# Altium schematic sheet BLDC_Ver0.SchDoc
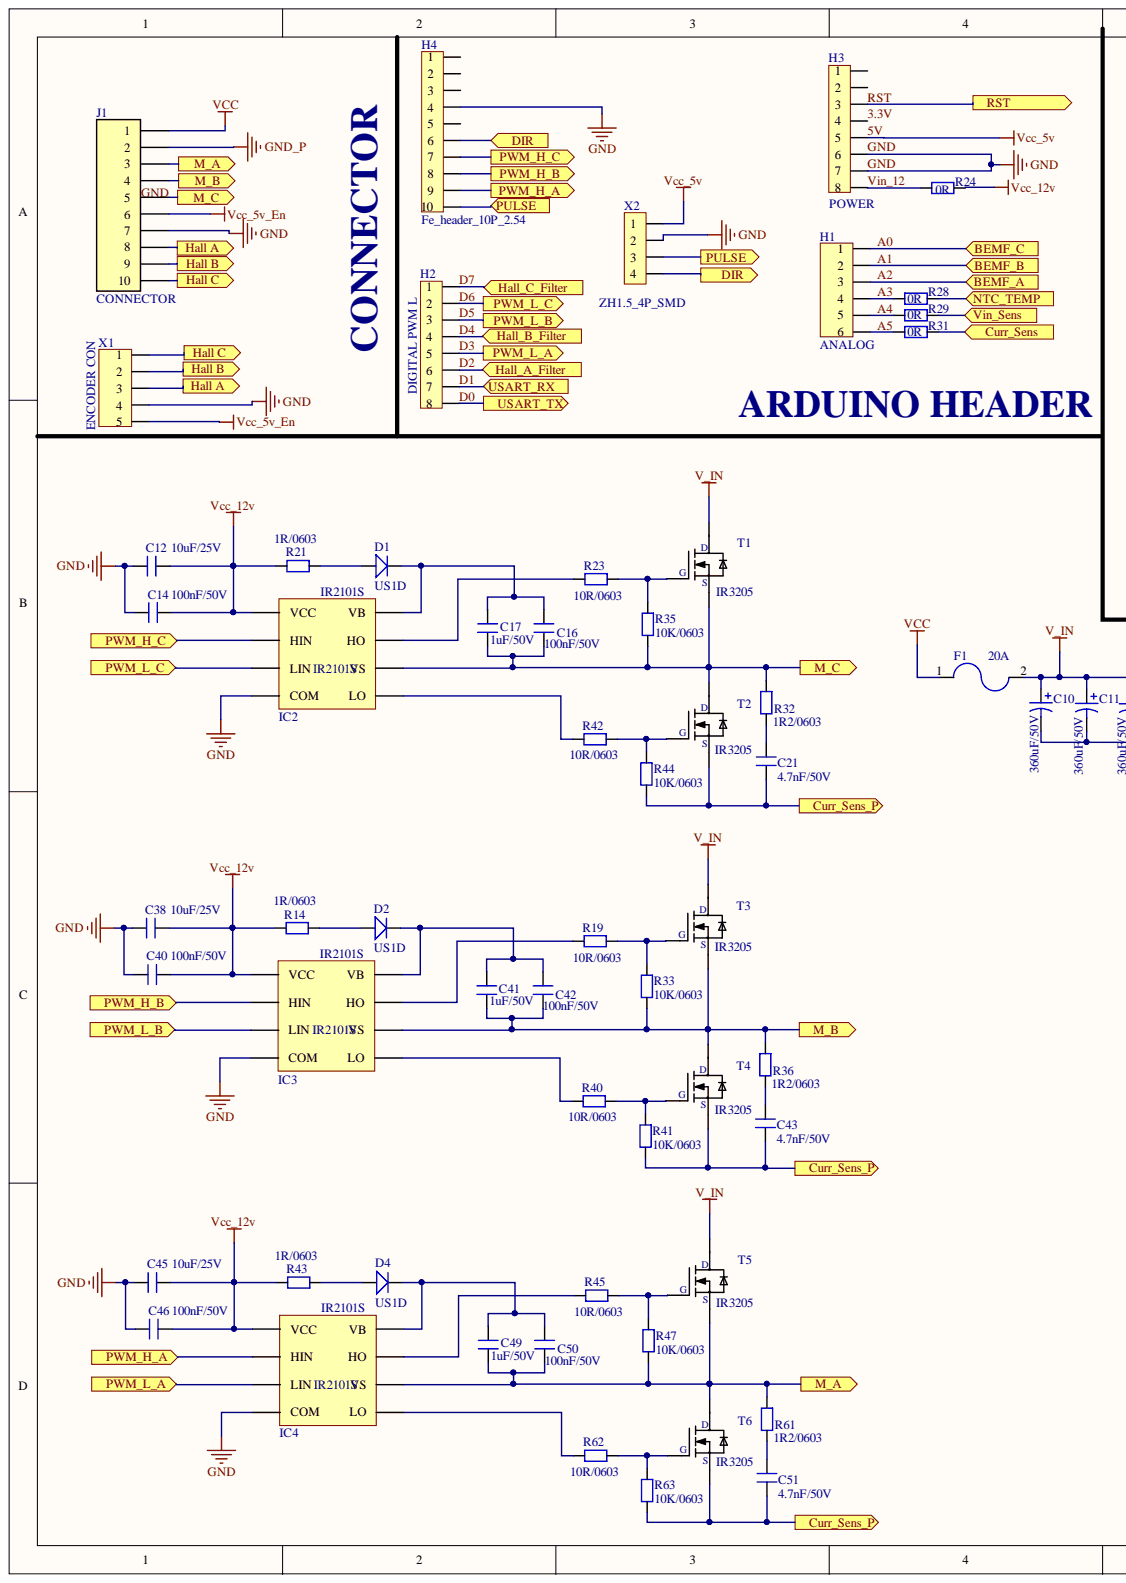
[diagram p0: BLDC_Ver0.SchDoc, left]
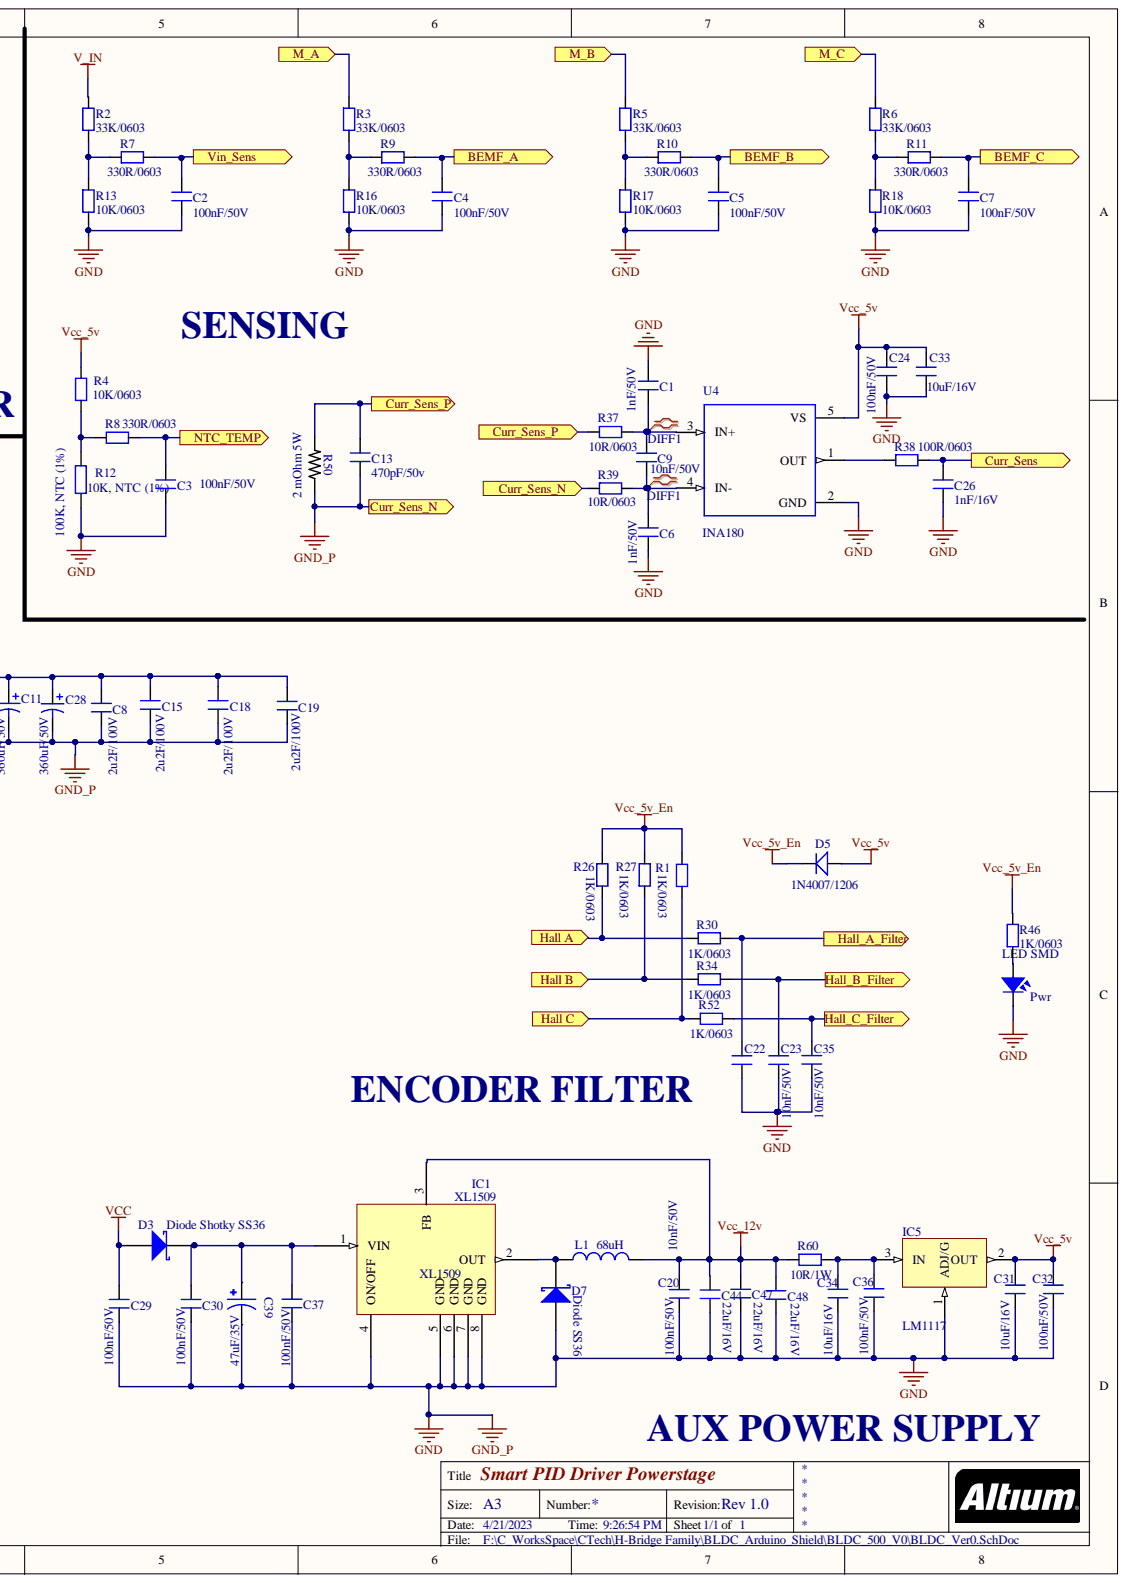
[diagram p1: BLDC_Ver0.SchDoc, right]

## Parts
C1  1nF/50V  [C0603]
C2  100nF/50V  [C0603]
C3  100nF/50V  [C0603]
C4  100nF/50V  [C0603]
C5  100nF/50V  [C0603]
C6  1nF/50V  [C0603]
C7  100nF/50V  [C0603]
C8  2u2F/100V  [C1206]
C9  10nF/50V  [C0805]
C10  360uF/50V  [*CAPAE830X1050N]
C11  360uF/50V  [*CAPAE830X1050N]
C12  10uF/25V  [C0805]
C13  470pF/50v  [C1206]
C14  100nF/50V  [C0603]
C15  2u2F/100V  [C1206]
C16  100nF/50V  [C0603]
C17  1uF/50V  [C0805]
C18  2u2F/100V  [C1206]
C19  2u2F/100V  [C1206]
C20  100nF/50V  [C0603]
C21  4.7nF/50V  [C0603]
C22  10nF/50V  [C0603]
C23  10nF/50V  [C0603]
C24  100nF/50V  [C0603]
C26  1nF/16V  [C0603]
C28  360uF/50V  [*CAPAE830X1050N]
C29  100nF/50V  [C0603]
C30  100nF/50V  [C0603]
C31  10uF/16V  [C0603]
C32  100nF/50V  [C0603]
C33  10uF/16V  [C0603]
C34  10uF/16V  [C0603]
C35  10nF/50V  [C0603]
C36  100nF/50V  [C0603]
C37  100nF/50V  [C0603]
C38  10uF/25V  [C0805]
C39  47uF/35V  [CAP_ALU_6.3x7.7]
C40  100nF/50V  [C0603]
C41  1uF/50V  [C0805]
C42  100nF/50V  [C0603]
C43  4.7nF/50V  [C0603]
C44  22uF/16V  [C1206]
C45  10uF/25V  [C0805]
C46  100nF/50V  [C0603]
C47  22uF/16V  [C1206]
C48  22uF/16V  [C1206]
C49  1uF/50V  [C0805]
C50  100nF/50V  [C0603]
C51  4.7nF/50V  [C0603]
D1  US1D  [SMA_DO-214AC]
D2  US1D  [SMA_DO-214AC]
D3  Diode Shotky SS36  [DIODE_SMC]
D4  US1D  [SMA_DO-214AC]
D5  1N4007/1206  [D_SOD123FL]
D7  Diode SS36  [*SMB_SS34(Primary)]
F1  20A  [Fuse_Nano2]
H1  ANALOG  [Fe_Header_6p_2.54]
H2  DIGITAL PWM L  [Fe_Header_8p_2.54]
H3  POWER  [Fe_Header_8p_2.54]
H4  Fe_header_10P_2.54  [Fe_Header_10p_2.54]
IC1  XL1509  [SOIC-8]
IC2  IR2101S  [SOIC-8]
IC3  IR2101S  [SOIC-8]
IC4  IR2101S  [SOIC-8]
IC5  LM1117  [SOT223_N]
J1  CONNECTOR  [PHOENIX_MSTB_10P_V_5.08]
L1  68uH  [*INDM7676X435N]
Pwr  LED SMD  [SM-LED-0805-Blue]
R1  1K/0603  [R0603]
R2  33K/0603  [R0603]
R3  33K/0603  [R0603]
R4  10K/0603  [R0603]
R5  33K/0603  [R0603]
R6  33K/0603  [R0603]
R7  330R/0603  [R0603]
R8  330R/0603  [R0603]
R9  330R/0603  [R0603]
R10  330R/0603  [R0603]
R11  330R/0603  [R0603]
R12  10K, NTC (1%)  value 100K, NTC (1%)  [RESC2012N]
R13  10K/0603  [R0603]
R14  1R/0603  [R0603]
R16  10K/0603  [R0603]
R17  10K/0603  [R0603]
R18  10K/0603  [R0603]
R19  10R/0603  [R0603]
R21  1R/0603  [R0603]
R23  10R/0603  [R0603]
R24  0R  [R0603]
R26  1K/0603  [R0603]
R27  1K/0603  [R0603]
R28  0R  [R0603]
R29  0R  [R0603]
R30  1K/0603  [R0603]
R31  0R  [R0603]
R32  1R2/0603  [R0603]
R33  10K/0603  [R0603]
R34  1K/0603  [R0603]
R35  10K/0603  [R0603]
R36  1R2/0603  [R0603]
R37  10R/0603  [R0603]
R38  100R/0603  [R0603]
R39  10R/0603  [R0603]
R40  10R/0603  [R0603]
R41  10K/0603  [R0603]
R42  10R/0603  [R0603]
R43  1R/0603  [R0603]
R44  10K/0603  [R0603]
R45  10R/0603  [R0603]
R46  1K/0603  [R0603]
R47  10K/0603  [R0603]
R50  2 mOhm 5W  [*RESC10550X48N]
R52  1K/0603  [R0603]
R60  10R/1W  [2512R]
R61  1R2/0603  [R0603]
R62  10R/0603  [R0603]
R63  10K/0603  [R0603]
T1  IR3205  [DDPAK_TO263-3]
T2  IR3205  [DDPAK_TO263-3]
T3  IR3205  [DDPAK_TO263-3]
T4  IR3205  [DDPAK_TO263-3]
T5  IR3205  [DDPAK_TO263-3]
T6  IR3205  [DDPAK_TO263-3]
U4  INA180  [SOT23-5]
X1  ENCODER CON  [Connector_ZH1.5_5P]
X2  ZH1.5_4P_SMD  [ZH1.5_4P_SMD_SIDE]

## Ports
BEMF_A, BEMF_B, BEMF_C, Curr_Sens, Curr_Sens_N, Curr_Sens_P, DIR, Hall A, Hall B, Hall C, Hall_A_Filter, Hall_B_Filter, Hall_C_Filter, M_A, M_B, M_C, NTC_TEMP, PULSE, PWM_H_A, PWM_H_B, PWM_H_C, PWM_L_A, PWM_L_B, PWM_L_C, RST, USART_RX, USART_TX, Vin_Sens

## Nets
V_IN: C8.2 C10.1 C11.1 C15.2 C18.2 C19.2 C28.1 F1.2 R2.1 T1.2 T3.2 T5.2
VCC: C29.2 D3.1 F1.1 J1.1
Vcc_5v_En: D5.2 J1.6 R1.2 R26.2 R27.2 R46.2 X1.5
Vcc_12v: C12.2 C14.1 C20.2 C38.2 C40.1 C44.2 C45.2 C46.1 C47.2 C48.2 IC1.3(FB) IC2.1(VCC) IC3.1(VCC) IC4.1(VCC) L1.2 R14.2 R21.2 R24.2 R43.2 R60.1
3.3V: H3.4
5V: C24.2 C31.2 C32.2 C33.2 D5.1 H3.5 IC5.2 R4.2 U4.5(VS) X2.1
A0: C7.2 H1.1 R11.2 ; port BEMF_C
A1: C5.2 H1.2 R10.2 ; port BEMF_B
A2: C4.2 H1.3 R9.2 ; port BEMF_A
A3: H1.4 R28.1
A4: H1.5 R29.1
A5: H1.6 R31.1
Curr_Sens: C26.2 R31.2 R38.2 ; port Curr_Sens
Curr_Sens_P: C13.2 C21.2 C43.2 C51.2 R37.1 R41.2 R44.2 R50.2 R63.2 T2.3 T4.3 T6.3 ; port Curr_Sens_P
D0: H2.8 ; port USART_TX
D1: H2.7 ; port USART_RX
D2: C22.2 H2.6 R30.2 ; port Hall_A_Filter
D3: H2.5 IC4.3(LIN) ; port PWM_L_A
D4: C23.2 H2.4 R34.2 ; port Hall_B_Filter
D5: H2.3 IC3.3(LIN) ; port PWM_L_B
D6: H2.2 IC2.3(LIN) ; port PWM_L_C
D7: C35.2 H2.1 R52.2 ; port Hall_C_Filter
DIR: H4.6 X2.4 ; port DIR
GND: C1.2 C2.1 C3.1 C4.1 C5.1 C6.1 C7.1 C8.1 C10.2 C11.2 C12.1 C13.1 C14.2 C15.1 C18.1 C19.1 C20.1 C22.1 C23.1 C24.1 C26.1 C28.2 C29.1 C30.1 C31.1 C32.1 C33.1 C34.1 C35.1 C36.1 C37.1 C38.1 C39.2 C40.2 C44.1 C45.1 C46.2 C47.1 C48.1 D7.1 H3.6 H3.7 H4.4 IC1.4(ON/OFF) IC1.5(GND) IC1.6(GND) IC1.7(GND) IC1.8(GND) IC2.4(COM) IC3.4(COM) IC4.4(COM) IC5.1 J1.2 J1.7 Pwr.2 R12.1 R13.2 R16.2 R17.2 R18.2 R39.1 R50.1 U4.2(GND) X1.4 X2.2 ; port Curr_Sens_N
Hall A: J1.8 R26.1 R30.1 X1.3 ; port Hall A
Hall B: J1.9 R27.1 R34.1 X1.2 ; port Hall B
Hall C: J1.10 R1.1 R52.1 X1.1 ; port Hall C
M_A: C49.1 C50.2 IC4.6(VS) J1.3 R3.1 R47.2 R61.1 T5.3 T6.2 ; port M_A
M_B: C41.1 C42.2 IC3.6(VS) J1.4 R5.1 R33.2 R36.1 T3.3 T4.2 ; port M_B
M_C: C16.2 C17.1 IC2.6(VS) J1.5 R6.1 R32.1 R35.2 T1.3 T2.2 ; port M_C
NTC_TEMP: C3.2 R8.1 R28.2 ; port NTC_TEMP
PULSE: H4.10 X2.3 ; port PULSE
PWM_H_A: H4.9 IC4.2(HIN) ; port PWM_H_A
PWM_H_B: H4.8 IC3.2(HIN) ; port PWM_H_B
PWM_H_C: H4.7 IC2.2(HIN) ; port PWM_H_C
RST: H3.3 ; port RST
Vin_12: H3.8 R24.1
Vin_Sens: C2.2 R7.2 R29.2 ; port Vin_Sens
NetC1_1: C1.1 C9.1 R37.2 U4.3(IN+)
NetC6_2: C6.2 C9.2 R39.2 U4.4(IN-)
NetC16_1: C16.1 C17.2 D1.2 IC2.8(VB)
NetC21_1: C21.1 R32.2
NetC30_2: C30.2 C37.2 C39.1 D3.2 IC1.1(VIN)
NetC34_2: C34.2 C36.2 IC5.3 R60.2
NetC41_2: C41.2 C42.1 D2.2 IC3.8(VB)
NetC43_1: C43.1 R36.2
NetC49_2: C49.2 C50.1 D4.2 IC4.8(VB)
NetC51_1: C51.1 R61.2
NetD1_1: D1.1 R21.1
NetD2_1: D2.1 R14.1
NetD4_1: D4.1 R43.1
NetD7_2: D7.2 IC1.2(OUT) L1.1
NetIC2_5: IC2.5(LO) R42.2
NetIC2_7: IC2.7(HO) R23.2
NetIC3_5: IC3.5(LO) R40.2
NetIC3_7: IC3.7(HO) R19.2
NetIC4_5: IC4.5(LO) R62.2
NetIC4_7: IC4.7(HO) R45.2
NetPwr_1: Pwr.1 R46.1
NetR2_2: R2.2 R7.1 R13.1
NetR3_2: R3.2 R9.1 R16.1
NetR4_1: R4.1 R8.2 R12.2
NetR5_2: R5.2 R10.1 R17.1
NetR6_2: R6.2 R11.1 R18.1
NetR19_1: R19.1 R33.1 T3.1
NetR23_1: R23.1 R35.1 T1.1
NetR38_1: R38.1 U4.1(OUT)
NetR40_1: R40.1 R41.1 T4.1
NetR42_1: R42.1 R44.1 T2.1
NetR45_1: R45.1 R47.1 T5.1
NetR62_1: R62.1 R63.1 T6.1

Not connected: H3 1 2; H4 1 2 3 5

## Library data of the parts
C1-C7 C14 C16 C20-C24 C26 C29-C37 C40 C42 C43 C46 C50 C51 = C0603: Ceramic Capacitor 0603; Component Kind: Standard; Library Name: Daniel_Lib.IntLib
C8 C13 C15 C18 C19 C44 C47 C48 = C1206: Ceramic Capacitor 1206; Component Kind: Standard; Library Name: Daniel_Lib.IntLib
C9 C12 C17 C38 C41 C45 C49 = C0805: Ceramic Capacitor 0805; Component Kind: Standard; Library Name: Daniel_Lib.IntLib
C10 C11 C28 = Cap Pol2: Polarized Capacitor (Axial); Component Kind: Standard; LatestRevisionDate: 17-Jul-2002; LatestRevisionNote: Re-released for DXP Platform.; PackageDescription: Polarized Capacitor; 2 Leads; PackageReference: POLAR0.8; Published: 8-Jun-2000; Library Name: TrieuLIB.IntLib; Publisher: Altium Limited
C39 = Cap_Alu_6.3x7.7: Cap Aluminum Lytic 6.3 X 7.7mm_ SMD; MF: Panasonic Electronic; MP: EEE-FTC331XAP; Availability: Unavailable; Component Kind: Standard; Package: Radial Panasonic; Price: None; SnapEDA_Link: https://www.snapeda.com/parts/EEE-FTC331XAP/Panasonic%20Electronic%20Components/view-part/?ref=snap; Library Name: Daniel_Lib.IntLib
D1 D2 D4 = Diode_RS1X: Diode Rectifier RS1X - 1A; Component Kind: Standard; Library Name: Daniel_Lib.IntLib
D3 D7 = D Schottky: Schottky Diode; Code_JEDEC: DO-214-AA; Component Kind: Standard; LatestRevisionDate: 17-Jul-2002; LatestRevisionNote: Re-released for DXP Platform.; Library Name: myIntegratedLIB.IntLib; PackageDescription: DO-214-AA/SMB; 2 C-Bend Leads; Body 5.3 x 3.6 mm, inc. leads (LxW); PackageReference: SMB; Published: 8-Jun-2000; Publisher: Altium Limited
D5 = Diode_SOD123FL: Diode SOD123FL; Component Kind: Standard; Library Name: Daniel_Lib.IntLib
F1 = Fuse_Nano2: LittelFuse Nano2 SMD 20A; Component Kind: Standard; ComponentLink1Description: Datasheet; ComponentLink1URL: https://www.littelfuse.com/~/media/electronics/datasheets/fuses/littelfuse_fuse_456_datasheet.pdf.pdf; Library Name: Daniel_Lib.IntLib
H1 = Fe_header_6P_2.54: Female Header 6P, Pitch 2.54mm; Component Kind: Standard; Library Name: Daniel_Lib.IntLib
H2 H3 = Fe_header_8P_2.54: Female Header 8P,Pitch 2.54mm; Component Kind: Standard; Library Name: Voltage_Regulator.IntLib
H4 = Fe_header_10P_2.54: Female Header 10, Pitch 2.54mm; Component Kind: Standard; Library Name: Daniel_Lib.IntLib
IC1 = XL1509: 2A 150KHz 40V Buck DC to DC Converter; Manufacturer: XLSEMI; Catologies: DC-DC Buck 1A 80V; Component Kind: Standard; ComponentLink1Description: Datasheet; ComponentLink1URL: http://www.xlsemi.com/datasheet/XL1509%20datasheet.pdf; Library Name: Daniel_Lib.IntLib
IC2-IC4 = IR2101S: HIGH AND LOW SIDE DRIVER 600V, 130mA/270mA; Manufacturer: XLSEMI; Catologies: DC-DC Buck 1A 80V; Component Kind: Standard; ComponentLink1Description: Datasheet; ComponentLink1URL: http://www.xlsemi.com/datasheet/XL1509%20datasheet.pdf; Library Name: IC.IntLib
IC5 = LM1117: Available in 1.8V, 2.5V, 2.85V, 3.3V, 5V, and  Adjustable Versions; Value: LM317; Component Kind: Standard; Library Name: TrieuLIB.IntLib
J1 = MSTB_10P_V_5.08: Phoenix MSTB 10P,Vertical, 5.08 MM; MF: TE Connectivity; MP: 1-1986981-0; Availability: Unavailable; Component Kind: Standard; Library Name: Connector.IntLib; Package: None; Price: None; SnapEDA_Link: https://www.snapeda.com/parts/1-1986981-0/TE%20Connectivity/view-part/3120448/?ref=snap; TE_purchase_URL: https://www.te.com/usa-en/product-1-1986981-0.html?te_bu=Cor&te_type=disp&te_campaign=seda_glo_cor-seda-global-disp-prtnr-fy19-seda-model-bom-cta_sma-317_1&elqCampaignId=32493
L1 = Inductor: Code_IEC: 1005; Code_JEITA: 0402; Component Kind: Standard; Description: Inductor; LatestRevisionDate: 29-May-2009; LatestRevisionNote: IPC-7351 Footprint Added.; PackageDescription: Chip Inductor; PackageReference: 0402-A; Published: 8-Jun-2000; Library Name: TrieuLIB.IntLib; Publisher: Altium Limited
Pwr = LED-SMD-0805: Component Kind: Standard; Library Name: syhaunguyen.IntLib
R1-R11 R13 R14 R16-R19 R21 R23 R24 R26-R47 R52 R61-R63 = R0603: Resistor SMD 0603 0.1W; Component Kind: Standard
  R46: Library Name: Voltage_Regulator.IntLib
  R1-R11 R13 R14 R16-R19 R21 R23 R24 R26-R45 R47 R52 R61-R63: Library Name: Daniel_Lib.IntLib
R12 = Res2: Resistor; Component Kind: Standard; LatestRevisionDate: 17-Jul-2002; LatestRevisionNote: Re-released for DXP Platform.; PackageDescription: Axial Device, Thru-Hole; 2 Leads; 0.4 in Pin Spacing; PackageReference: AXIAL-0.4; Published: 8-Jun-2000; Library Name: Daniel_Lib.IntLib; Publisher: Altium Limited
R50 = TLRS105030DR001FTDG: SMD Shunt resistor 3W R001 1%; MF: TE Connectivity; Availability: Unavailable; Component Kind: Standard; Library Name: myIntegratedLIB.IntLib; MP: TLRS105030DR001FTDG; Package: 4120 TE Connectivity; Price: None; SnapEDA_Link: https://www.snapeda.com/parts/TLRS105030DR001FTDG/TE%20Connectivity/view-part/?ref=snap
R60 = R2512: Resistor SMD 2512; Component Kind: Standard; Library Name: Daniel_Lib.IntLib
T1-T6 = MOSFET_D2PAK: MOSFET D2PAK_TO263; Component Kind: Standard; Library Name: Daniel_Lib.IntLib
U4 = INA180: 26V, Low- or High-Side Voltage Output Current Sense Amplifier 5-SOT-23 -40 to 125; MF: Texas Instruments; MP: INA180A3IDBVR; Availability: Unavailable; Component Kind: Standard; Library Name: Voltage_Regulator.IntLib; Package: SOT-23 Texas Instruments; Price: None; SnapEDA_Link: https://www.snapeda.com/parts/INA180A3IDBVR/Texas%20Instruments/view-part/?ref=snap
X1 = Connector_5P_ZH1.5: ZH1.5 Connector 5P, Pitch 1.5mm; Component Kind: Standard; Library Name: Daniel_Lib.IntLib
X2 = ZH1.5_4P_SMD: ZH1.5 Connector 4P,Pitch 1.5mm, SMD part,; Component Kind: Standard; Library Name: Daniel_Lib.IntLib
